# Altium schematic sheet DAC_filter_stage.SchDoc
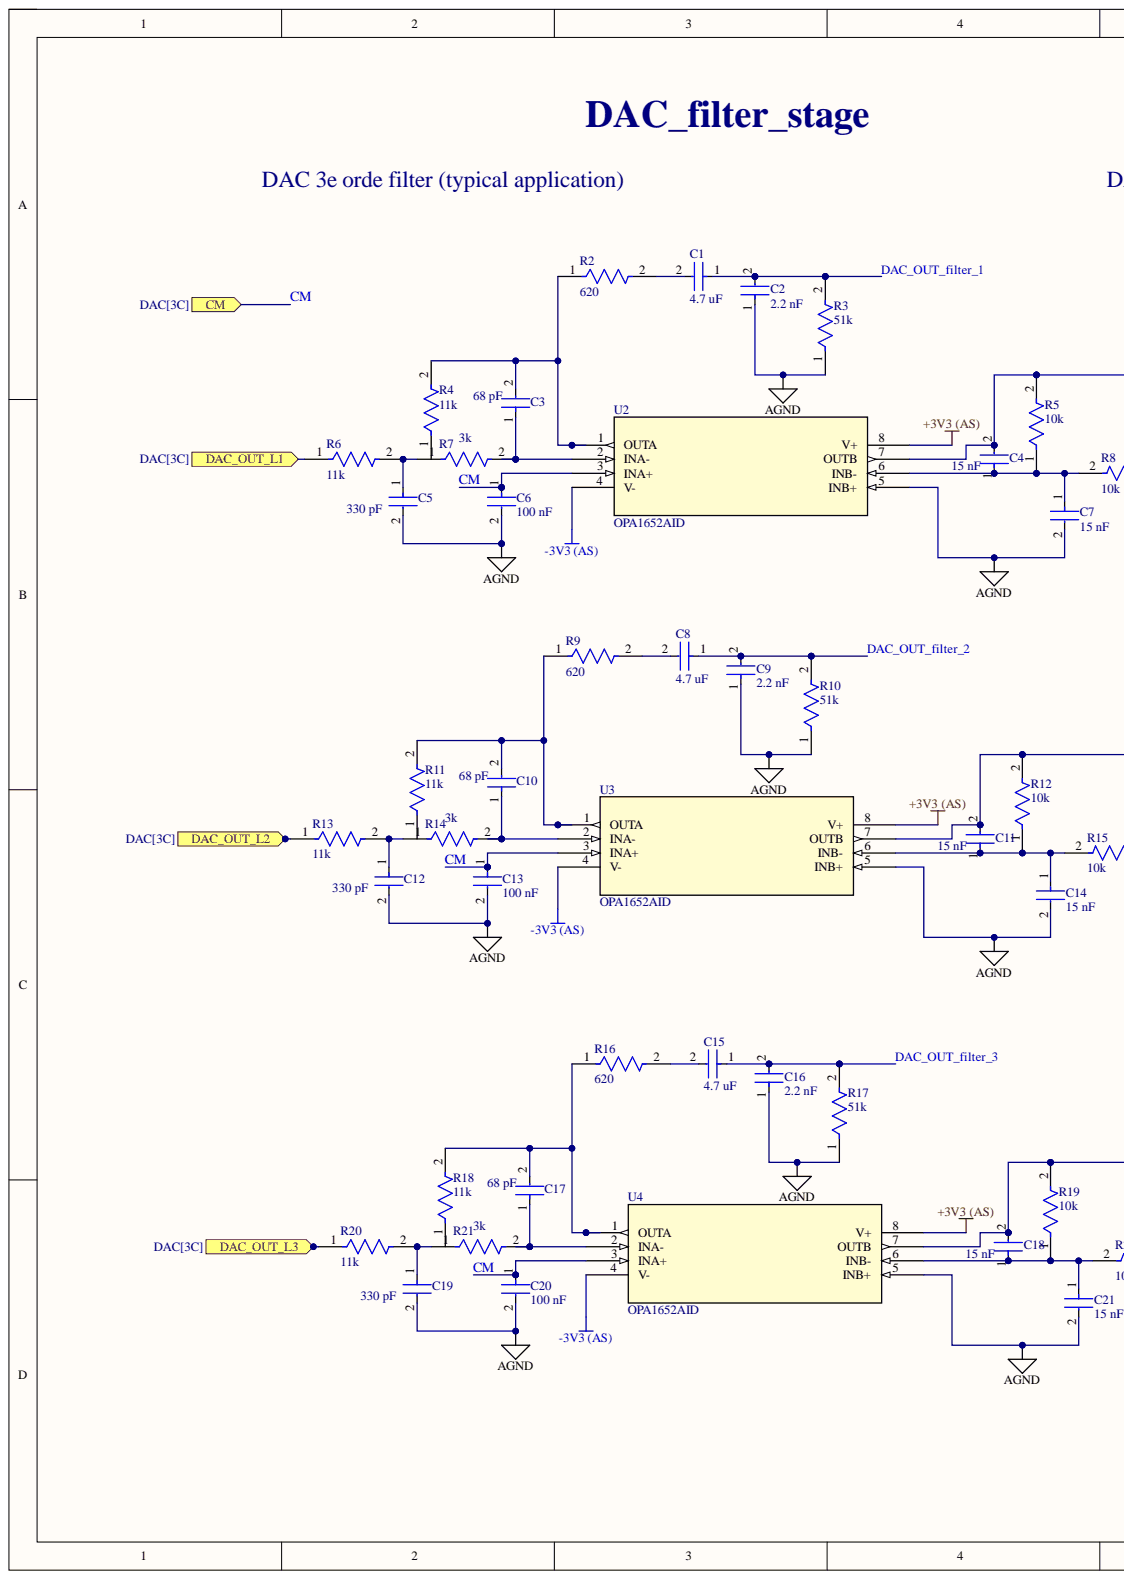
[diagram p0: DAC_filter_stage.SchDoc, left]
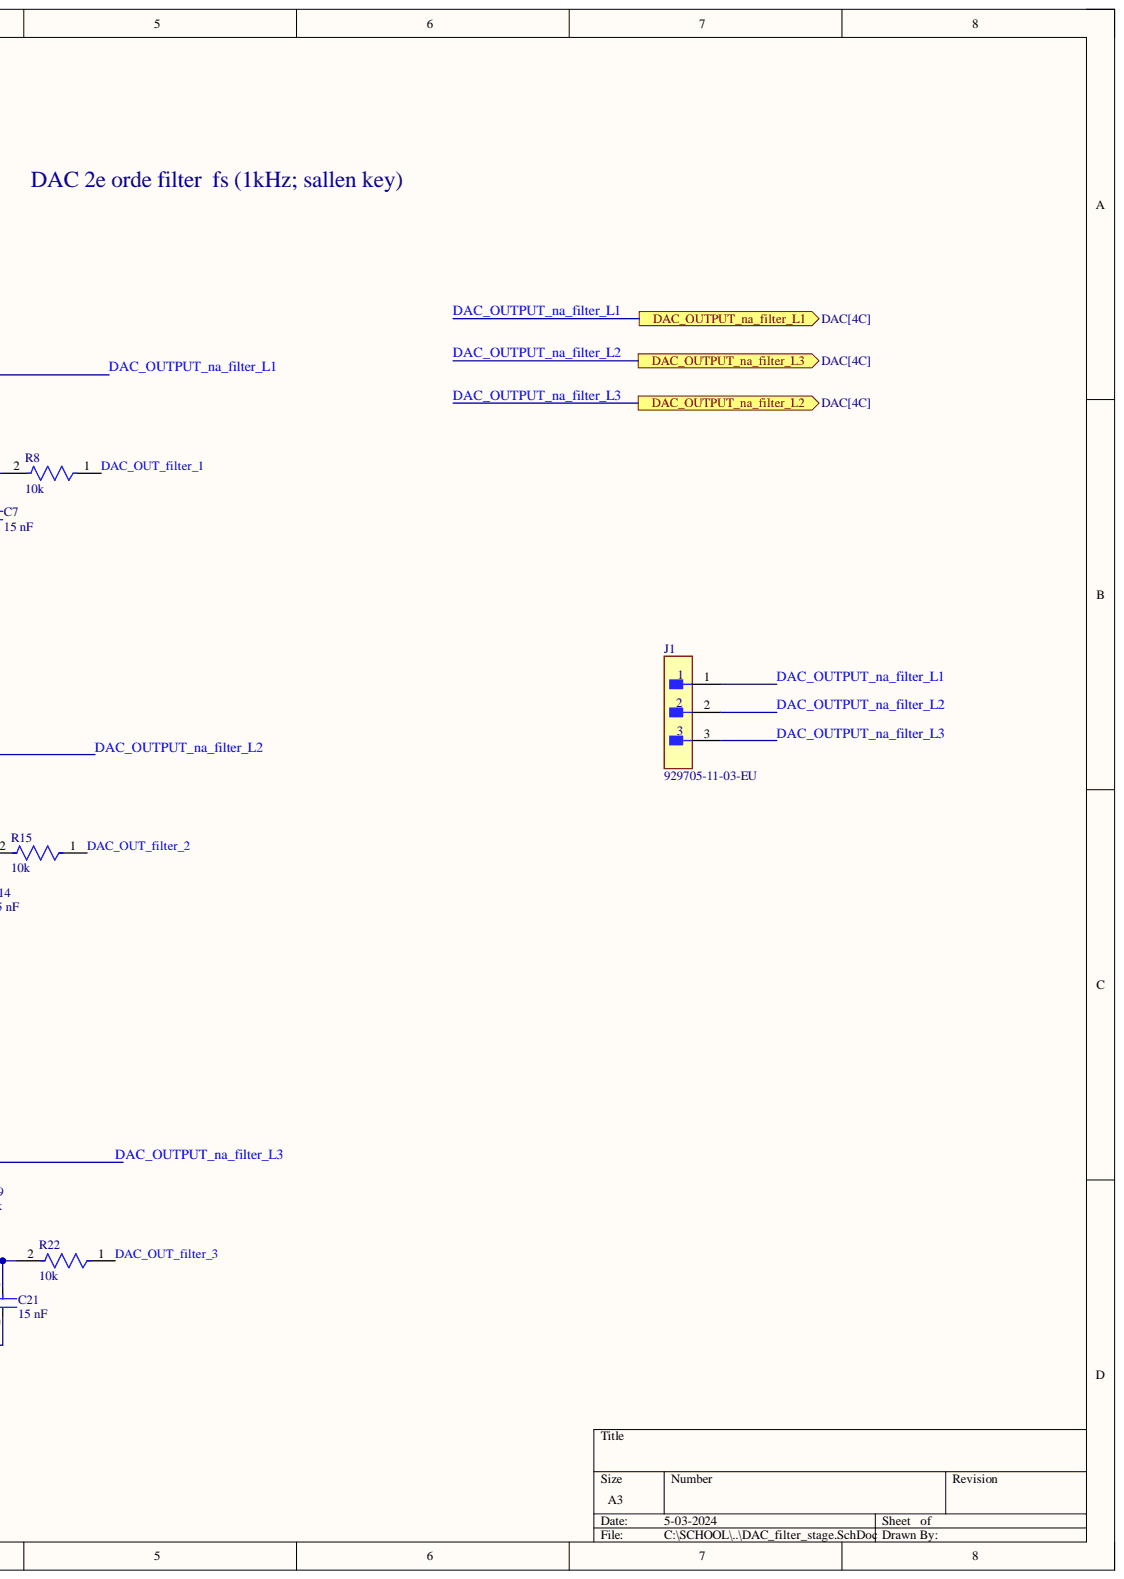
[diagram p1: DAC_filter_stage.SchDoc, right]

## Parts
C1  4.7 uF  [FP-C0603C-CF-MFG]
C2  2.2 nF  [FP-AC0603-MFG]
C3  68 pF  [FP-AC0603-MFG]
C4  15 nF  [FP-C0603C-CF-MFG]
C5  330 pF  [FP-AC0603-MFG]
C6  100 nF  [FP-C0603C-CF-MFG]
C7  15 nF  [FP-C0603C-CF-MFG]
C8  4.7 uF  [FP-C0603C-CF-MFG]
C9  2.2 nF  [FP-AC0603-MFG]
C10  68 pF  [FP-AC0603-MFG]
C11  15 nF  [FP-C0603C-CF-MFG]
C12  330 pF  [FP-AC0603-MFG]
C13  100 nF  [FP-C0603C-CF-MFG]
C14  15 nF  [FP-C0603C-CF-MFG]
C15  4.7 uF  [FP-C0603C-CF-MFG]
C16  2.2 nF  [FP-AC0603-MFG]
C17  68 pF  [FP-AC0603-MFG]
C18  15 nF  [FP-C0603C-CF-MFG]
C19  330 pF  [FP-AC0603-MFG]
C20  100 nF  [FP-C0603C-CF-MFG]
C21  15 nF  [FP-C0603C-CF-MFG]
J1  929705-11-03-EU  [FP-929705-11-03-EU-MFG]
R2  620  [FP-RC1608-IPC_B]
R3  51k  [FP-RC1608-IPC_B]
R4  11k  [FP-RC1608-IPC_B]
R5  10k  [FP-RC1608-IPC_B]
R6  11k  [FP-RC1608-IPC_B]
R7  3k  [FP-RC1608-IPC_B]
R8  10k  [FP-RC1608-IPC_B]
R9  620  [FP-RC1608-IPC_B]
R10  51k  [FP-RC1608-IPC_B]
R11  11k  [FP-RC1608-IPC_B]
R12  10k  [FP-RC1608-IPC_B]
R13  11k  [FP-RC1608-IPC_B]
R14  3k  [FP-RC1608-IPC_B]  (value not drawn)
R15  10k  [FP-RC1608-IPC_B]
R16  620  [FP-RC1608-IPC_B]
R17  51k  [FP-RC1608-IPC_B]
R18  11k  [FP-RC1608-IPC_B]
R19  10k  [FP-RC1608-IPC_B]
R20  11k  [FP-RC1608-IPC_B]
R21  3k  [FP-RC1608-IPC_B]  (value not drawn)
R22  10k  [FP-RC1608-IPC_B]
U2  OPA1652AID  [D8]
U3  OPA1652AID  [D8]
U4  OPA1652AID  [D8]

## Ports
CM, DAC_OUT_L1, DAC_OUT_L2, DAC_OUT_L3, DAC_OUTPUT_na_filter_L1, DAC_OUTPUT_na_filter_L2, DAC_OUTPUT_na_filter_L3

## Nets
+3V3 (AS): U2.8(V+) U3.8(V+) U4.8(V+)
-3V3 (AS): U2.4(V-) U3.4(V-) U4.4(V-)
AGND: C2.1 C5.2 C6.2 C7.2 C9.1 C12.2 C13.2 C14.2 C16.1 C19.2 C20.2 C21.2 R3.1 R10.1 R17.1 U2.5(INB+) U3.5(INB+) U4.5(INB+)
CM: C6.1 C13.1 C20.1 U2.3(INA+) U3.3(INA+) U4.3(INA+) ; port CM
DAC_OUT_filter_1: C1.1 C2.2 R3.2 R8.1
DAC_OUT_filter_2: C8.1 C9.2 R10.2 R15.1
DAC_OUT_filter_3: C15.1 C16.2 R17.2 R22.1
DAC_OUT_L1: R6.1 ; port DAC_OUT_L1
DAC_OUT_L2: R13.1 ; port DAC_OUT_L2
DAC_OUT_L3: R20.1 ; port DAC_OUT_L3
DAC_OUTPUT_na_filter_L1: C4.2 J1.1 R5.2 U2.7(OUTB) ; port DAC_OUTPUT_na_filter_L1
DAC_OUTPUT_na_filter_L2: C11.2 J1.2 R12.2 U3.7(OUTB) ; port DAC_OUTPUT_na_filter_L3
DAC_OUTPUT_na_filter_L3: C18.2 J1.3 R19.2 U4.7(OUTB) ; port DAC_OUTPUT_na_filter_L2
NetC1_2: C1.2 R2.2
NetC3_1: C3.1 R7.2 U2.2(INA-)
NetC3_2: C3.2 R2.1 R4.2 U2.1(OUTA)
NetC4_1: C4.1 C7.1 R5.1 R8.2 U2.6(INB-)
NetC5_1: C5.1 R6.2 R7.1
NetC8_2: C8.2 R9.2
NetC10_1: C10.1 R14.2 U3.2(INA-)
NetC10_2: C10.2 R9.1 R11.2 U3.1(OUTA)
NetC11_1: C11.1 C14.1 R12.1 R15.2 U3.6(INB-)
NetC12_1: C12.1 R13.2 R14.1
NetC15_2: C15.2 R16.2
NetC17_1: C17.1 R21.2 U4.2(INA-)
NetC17_2: C17.2 R16.1 R18.2 U4.1(OUTA)
NetC18_1: C18.1 C21.1 R19.1 R22.2 U4.6(INB-)
NetC19_1: C19.1 R20.2 R21.1

Not connected: R4 1; R11 1; R18 1

## Library data of the parts
C1 C8 C15 = CMP-2006-03056-2: CAP CER 4.7 UF 50V 5% X7R 0603; Generic part Eurocircuits: GPC0603475-10; Manufacturer: KEMET; Manufacturer Part Number: C0603C104J5RACTU; Automotive Grade: Grade 1; Capacitance: 4.7 μF; Material: Ceramic; Temperature Coefficient: 30ppm/°C; Tolerance: 0.05; Value: 4.7 μF; Automotive: Yes; Category: Cap; Component Kind: Standard; ComponentLink2Description: Datasheet; ComponentLink2URL: https://api.kemet.com/component-edge/download/datasheet/C0603C100J5GACAUTO.pdf; Device Class L1: Passive Components; Device Class L2: Capacitors; Device Class L3: Ceramic Capacitors; Digikey Description: CAP CER 0.1UF 50V 5% X7R 0603; Height: 0.87mm; Lead Free: Yes; Library Name: Altium Content Vault; Mouser Description: Multilayer Ceramic Capacitors MLCC - SMD/SMT 50volts 0.1uF X7R 5%; Octopart: https://octopart.com/c0603c104j5ractu-kemet-10440638; Package: C0603C-CF; RoHS: TRUE; Temperature: -55°C to +125°C; Temperature Characteristic: X7R; Temperature Range High: +125°C; Temperature Range Low: -55°C; Voltage Rating: 50 V
C2 C9 C16 = CMP-03422-001062-1: AC0603 2.2 pF NP0 5.00% 50 V; Generic part Eurocircuits: GPC0603222; Manufacturer: Yageo; Manufacturer Part Number: AC0603JRNPO9BN271; Automotive Grade: Grade 1; Material: Ceramic; Temperature Coefficient: 15%; Tolerance: 0.05; Voltage Rating: 50 V; Automotive: Yes; Capacitance: 2.2 nF; Category: Cap; Component Kind: Standard; ComponentLink2Description: Datasheet; ComponentLink2URL: http://www.yageo.com/documents/recent/UPY-AC_NP0X7R_16V-to-630V_12.pdf; Device Class L1: Passive Components; Device Class L2: Capacitors; Device Class L3: Ceramic Capacitors; Footprint Url: http://www.yageo.com/exep/pages/download/literatures/UPY-C_GEN_24.pdf; Height: 0.9mm; Ipc Land Pattern Name: CAPC16080X80; Lead Free: Yes; Library Name: Altium Content Vault; Octopart: https://octopart.com/ac0603jrnpo9bn271-yageo-87214073; Package: AC0603; RoHS: TRUE; Temperature: -55°C to +125°C; Temperature Characteristic: NP0; Temperature Range High: +125°C; Temperature Range Low: -55°C; Value: 2.2 nF
C3 C10 C17 = CMP-03422-001062-1: AC0603 68 pF NP0 5.00% 50 V; Generic part Eurocircuits: GPC0603680; Manufacturer: Yageo; Manufacturer Part Number: AC0603JRNPO9BN271; Automotive Grade: Grade 1; Material: Ceramic; Temperature Coefficient: 15%; Tolerance: 0.05; Voltage Rating: 50 V; Automotive: Yes; Capacitance: 68 pF; Category: Cap; Component Kind: Standard; ComponentLink2Description: Datasheet; ComponentLink2URL: http://www.yageo.com/documents/recent/UPY-AC_NP0X7R_16V-to-630V_12.pdf; Device Class L1: Passive Components; Device Class L2: Capacitors; Device Class L3: Ceramic Capacitors; Footprint Url: http://www.yageo.com/exep/pages/download/literatures/UPY-C_GEN_24.pdf; Height: 0.9mm; Ipc Land Pattern Name: CAPC16080X80; Lead Free: Yes; Library Name: Altium Content Vault; Octopart: https://octopart.com/ac0603jrnpo9bn271-yageo-87214073; Package: AC0603; RoHS: TRUE; Temperature: -55°C to +125°C; Temperature Characteristic: NP0; Temperature Range High: +125°C; Temperature Range Low: -55°C; Value: 68 pF
C4 C7 C11 C14 C18 C21 = CMP-2006-03056-2: CAP CER 15 nF 50V 5% X7R 0603                                           Eurocircuits generic components:                                 GPC0603153; Manufacturer: KEMET; Manufacturer Part Number: C0603C104J5RACTU; Automotive Grade: Grade 1; Material: Ceramic; Temperature Coefficient: 30ppm/°C; Tolerance: 0.05; Automotive: Yes; Capacitance: 15 nF; Category: Cap; Component Kind: Standard; ComponentLink2Description: Datasheet; ComponentLink2URL: https://api.kemet.com/component-edge/download/datasheet/C0603C100J5GACAUTO.pdf; Device Class L1: Passive Components; Device Class L2: Capacitors; Device Class L3: Ceramic Capacitors; Digikey Description: CAP CER 0.1UF 50V 5% X7R 0603; Generic part Eurocircuits: GPC0603153; Height: 0.87mm; Lead Free: Yes; Library Name: Altium Content Vault; Mouser Description: Multilayer Ceramic Capacitors MLCC - SMD/SMT 50volts 0.1uF X7R 5%; Octopart: https://octopart.com/c0603c104j5ractu-kemet-10440638; Package: C0603C-CF; RoHS: TRUE; Temperature: -55°C to +125°C; Temperature Characteristic: X7R; Temperature Range High: +125°C; Temperature Range Low: -55°C; Value: 15 nF; Voltage Rating: 50 V
C5 C12 C19 = CMP-03422-001062-1: AC0603 330 pF NP0 5.00% 50 V; Generic part Eurocircuits: GPC0603331; Manufacturer: Yageo; Manufacturer Part Number: AC0603JRNPO9BN271; Automotive Grade: Grade 1; Material: Ceramic; Temperature Coefficient: 15%; Tolerance: 0.05; Voltage Rating: 50 V; Automotive: Yes; Capacitance: 330 pF; Category: Cap; Component Kind: Standard; ComponentLink2Description: Datasheet; ComponentLink2URL: http://www.yageo.com/documents/recent/UPY-AC_NP0X7R_16V-to-630V_12.pdf; Device Class L1: Passive Components; Device Class L2: Capacitors; Device Class L3: Ceramic Capacitors; Footprint Url: http://www.yageo.com/exep/pages/download/literatures/UPY-C_GEN_24.pdf; Height: 0.9mm; Ipc Land Pattern Name: CAPC16080X80; Lead Free: Yes; Library Name: Altium Content Vault; Octopart: https://octopart.com/ac0603jrnpo9bn271-yageo-87214073; Package: AC0603; RoHS: TRUE; Temperature: -55°C to +125°C; Temperature Characteristic: NP0; Temperature Range High: +125°C; Temperature Range Low: -55°C; Value: 330 pF
C6 C13 C20 = CMP-2006-03056-2: CAP CER 100 nF 50V 5% X7R 0603; Generic part Eurocircuits: GPC0603104; Manufacturer: KEMET; Manufacturer Part Number: C0603C104J5RACTU; Automotive Grade: Grade 1; Material: Ceramic; Temperature Coefficient: 30ppm/°C; Tolerance: 0.05; Automotive: Yes; Capacitance: 100 nF; Category: Cap; Component Kind: Standard; ComponentLink2Description: Datasheet; ComponentLink2URL: https://api.kemet.com/component-edge/download/datasheet/C0603C100J5GACAUTO.pdf; Device Class L1: Passive Components; Device Class L2: Capacitors; Device Class L3: Ceramic Capacitors; Digikey Description: CAP CER 0.1UF 50V 5% X7R 0603; Height: 0.87mm; Lead Free: Yes; Library Name: Altium Content Vault; Mouser Description: Multilayer Ceramic Capacitors MLCC - SMD/SMT 50volts 0.1uF X7R 5%; Octopart: https://octopart.com/c0603c104j5ractu-kemet-10440638; Package: C0603C-CF; RoHS: TRUE; Temperature: -55°C to +125°C; Temperature Characteristic: X7R; Temperature Range High: +125°C; Temperature Range Low: -55°C; Value: 100 nF; Voltage Rating: 50 V
J1 = CMP-05379-000017-1: CONN HEADER VERT 3POS 2.54MM  Euro circruits generic component:  GPHA-1X03; Connector Type: Male Pin; Current Rating: 2.5A; Gender: Male; Number of Positions: 3; Voltage Rating: 1.5kV; Color: Black; Component Kind: Standard; Contact Gender: Male; Contact Material: Copper Alloy; Contact Mating Length: 0.318inch; Contact Plating: Gold; Contact Type: Male Pin; Depth: 2.92mm; Eurocircuits_generic_part_number: GPHA-1X03; Housing Color: Black; Housing Material: Polycyclohexylenedimethylene; Length: 7.6mm; Library Name: Altium Content Vault; Max Current Rating: 2.5A; Max Operating Temperature: 105°C; Min Operating Temperature: -40°C; Mount: Through Hole; Number of Rows: 1; Orientation: Straight; Package Quantity: 100; Packaging: Bulk; Pitch: 2.54mm; RoHS Compliant: Yes; Series: 929; Termination: Solder
R2 R9 R16 = CMP-13261-004935-1: Res Thick Film 0603 620Ω 1% 0.1W ±100ppm/°C Molded SMD T/R; Generic part Eurocircuits: GPR0603620R; Power Rating: 100mW; Voltage Rating (DC): 50V; Case/Package: 0603; Component Kind: Standard; Composition: Thick Film; Contact Plating: Tin; Depth: 0.8mm; Lead Free: Lead Free; Length: 1.6mm; Library Name: Altium Content Vault; Max Operating Temperature: 155°C; Military Standard: Not; Min Operating Temperature: -55°C; Packaging: Tape and Reel; Radiation Hardening: No; Resistance: 620; Temperature Coefficient: 100ppm/°C; Tolerance: 1%; Width: 800um
R3 R10 R17 = CMP-13261-004935-1: Res Thick Film 0603 51kΩ 1% 0.1W ±100ppm/°C Molded SMD T/R; Generic part Eurocircuits: GPR060351K; Power Rating: 100mW; Voltage Rating (DC): 50V; Case/Package: 0603; Component Kind: Standard; Composition: Thick Film; Contact Plating: Tin; Depth: 0.8mm; Lead Free: Lead Free; Length: 1.6mm; Library Name: Altium Content Vault; Max Operating Temperature: 155°C; Military Standard: Not; Min Operating Temperature: -55°C; Packaging: Tape and Reel; Radiation Hardening: No; Resistance: 51k; Temperature Coefficient: 100ppm/°C; Tolerance: 1%; Width: 800um
R4 R6 R11 R13 R18 R20 = CMP-13261-004935-1: Res Thick Film 0603 11kΩ 1% 0.1W ±100ppm/°C Molded SMD T/R; Generic part Eurocircuits: GPR060311K; Power Rating: 100mW; Voltage Rating (DC): 50V; Case/Package: 0603; Component Kind: Standard; Composition: Thick Film; Contact Plating: Tin; Depth: 0.8mm; Lead Free: Lead Free; Length: 1.6mm; Library Name: Altium Content Vault; Max Operating Temperature: 155°C; Military Standard: Not; Min Operating Temperature: -55°C; Packaging: Tape and Reel; Radiation Hardening: No; Resistance: 11k; Temperature Coefficient: 100ppm/°C; Tolerance: 1%; Width: 800um
R5 R8 R12 R15 R19 R22 = CMP-13261-004935-1: Res Thick Film 0603 10kΩ 1% 0.1W ±100ppm/°C Molded SMD T/R    Generic part Eurocircuits:   GPR060310K; Power Rating: 100mW; Voltage Rating (DC): 50V; Case/Package: 0603; Component Kind: Standard; Composition: Thick Film; Contact Plating: Tin; Depth: 0.8mm; Generic part Eurocircuits: GPR060310K; Lead Free: Lead Free; Length: 1.6mm; Library Name: Altium Content Vault; Max Operating Temperature: 155°C; Military Standard: Not; Min Operating Temperature: -55°C; Packaging: Tape and Reel; Radiation Hardening: No; Resistance: 10k; Temperature Coefficient: 100ppm/°C; Tolerance: 1%; Width: 800um
R7 R14 R21 = CMP-13261-004935-1: Res Thick Film 0603 3kΩ 1% 0.1W ±100ppm/°C Molded SMD T/R; Generic part Eurocircuits: GPR06033K; Power Rating: 100mW; Voltage Rating (DC): 50V; Case/Package: 0603; Component Kind: Standard; Composition: Thick Film; Contact Plating: Tin; Depth: 0.8mm; Lead Free: Lead Free; Length: 1.6mm; Library Name: Altium Content Vault; Max Operating Temperature: 155°C; Military Standard: Not; Min Operating Temperature: -55°C; Packaging: Tape and Reel; Radiation Hardening: No; Resistance: 3k; Temperature Coefficient: 100ppm/°C; Tolerance: 1%; Width: 800um
U2-U4 = OPA1652AID: No Description Available; Mfr_Name: Texas Instruments; Component Kind: Standard; Library Name: OPA1652AID.IntLib; RefDes: RefDes; Type: Type
